# Altium schematic sheet CurrentSensor.SchDoc(CurrentSensor)
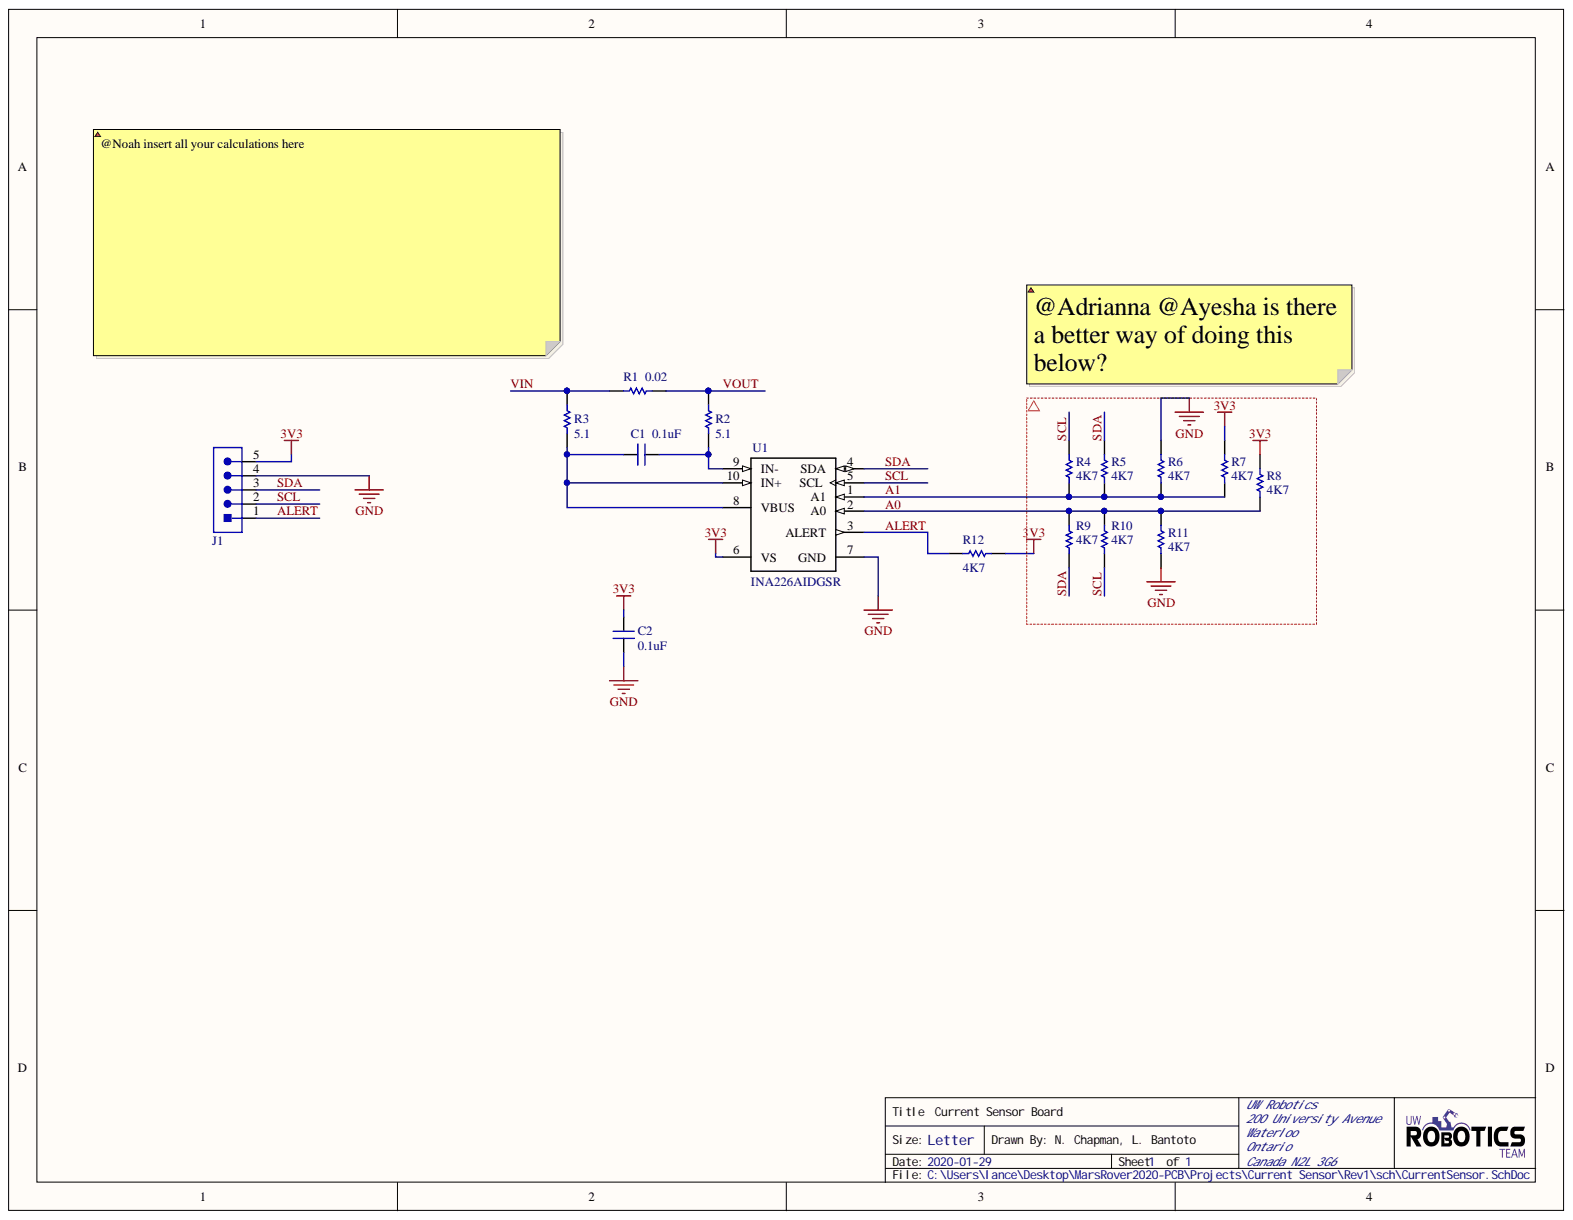
[diagram p0: CurrentSensor.SchDoc]

## Parts
C1  value 0.1uF  [CAPC2012X140N]  (hidden comment: CAP_0.1uF_50V_0805)
C2  value 0.1uF  [CAPC2012X140N]  (hidden comment: CAP_0.1uF_50V_0805)
J1  CON_5M_SM4  [JST-B5B-SM4-TB]  (value not drawn)
R1  value 0.02  [RESC6532X75N]  (hidden comment: RES_20m_2512)
R2  value 5.1  [RESC2013X70X40LL20T20]  (hidden comment: RES_5.1_0805)
R3  value 5.1  [RESC2013X70X40LL20T20]  (hidden comment: RES_5.1_0805)
R4  value 4K7  [RESC2012X60N]  (hidden comment: RES_4K7_0805)
R5  value 4K7  [RESC2012X60N]  (hidden comment: RES_4K7_0805)
R6  value 4K7  [RESC2012X60N]  (hidden comment: RES_4K7_0805)
R7  value 4K7  [RESC2012X60N]  (hidden comment: RES_4K7_0805)
R8  value 4K7  [RESC2012X60N]  (hidden comment: RES_4K7_0805)
R9  value 4K7  [RESC2012X60N]  (hidden comment: RES_4K7_0805)
R10  value 4K7  [RESC2012X60N]  (hidden comment: RES_4K7_0805)
R11  value 4K7  [RESC2012X60N]  (hidden comment: RES_4K7_0805)
R12  value 4K7  [RESC2012X60N]  (hidden comment: RES_4K7_0805)
U1  INA226AIDGSR  [INA226AIDGSR]

## Nets
3V3: C2.2 J1.5 R7.2 R8.2 R12.2 U1.6(VS)
GND: C2.1 J1.4 R6.2 R11.2 U1.7(GND)
A0: R8.1 R9.1 R10.1 R11.1 U1.2(A0)
A1: R4.1 R5.1 R6.1 R7.1 U1.1(A1)
ALERT: J1.1 R12.1 U1.3(ALERT)
SCL: J1.2 R4.2 R10.2 U1.5(SCL)
SDA: J1.3 R5.2 R9.2 U1.4(SDA)
VIN: R1.1 R3.2
VOUT: R1.2 R2.2
NetC1_1: C1.1 R3.1 U1.8(VBUS) U1.10(IN+)
NetC1_2: C1.2 R2.1 U1.9(IN-)

## Library data of the parts
C1 C2 = CAP_0.1uF_50V_0805: CAP CER 0.1UF 50V X7R 0805; Manufacturer Part Number 1: GCD21BR71H104KA01L; Manufacturer 1: Murata; Tolerance: 10%; Capacitance: 100nF; Width: 0.049inch; Voltage Rating: 50V; Thickness: 0.049inch; Termination: SMD/SMT; Supplier Part Number 1: 490-11955-1-ND; Supplier 1: Digi-Key; Ratings: AEC-Q200; Mount: Surface Mount; Min Operating Temperature: -55°C; Max Operating Temperature: 125°C; Length: 2mm; Height: 1.25mm; Dielectric: X7R; Depth: 1.25mm; ComponentLink1URL: https://datasheet.ciiva.com/29537/c03e-29537701.pdf?src-supplier=Digi-Key; ComponentLink1Description: https://datasheet.ciiva.com/29537/c03e-29537701.pdf?src-supplier=Digi-Key; Component Kind: Standard; Case Code (Metric): 2012; Case Code (Imperial): 0805; Case/Package: 0805; Library Name: UWRT_Schematic_Library_2020.SchLib
J1 = CON_5M_SM4: CONN HEADER SMD 5POS 2MM; Manufacturer Part Number: B5B-PH-SM4-TB(LF)(SN); Manufacturer: JST; Max Voltage Rating: 100V; Max Current Rating: 2A; Supplier Part Number 1: 455-1737-1-ND; Supplier 1: Digi-Key; Pitch: 2mm; ComponentLink5URL: https://datasheet.ciiva.com/16344/eph-16344920.pdf?src-supplier=Future+Electronics; ComponentLink5Description: https://datasheet.ciiva.com/16344/eph-16344920.pdf?src-supplier=Future+Electronics; ComponentLink4URL: https://datasheet.ciiva.com/730/eph-730612.pdf?src-supplier=Digi-Key; ComponentLink4Description: https://datasheet.ciiva.com/730/eph-730612.pdf?src-supplier=Digi-Key; ComponentLink3URL: https://datasheet.ciiva.com/712/eph-712596.pdf?src-supplier=Digi-Key; ComponentLink3Description: https://datasheet.ciiva.com/712/eph-712596.pdf?src-supplier=Digi-Key; ComponentLink2URL: https://datasheet.ciiva.com/712/eph-712595.pdf?src-supplier=Digi-Key; ComponentLink2Description: https://datasheet.ciiva.com/712/eph-712595.pdf?src-supplier=Digi-Key; ComponentLink1URL: https://datasheet.ciiva.com/11749/0900766b80ddea81-11749188.pdf?src-supplier=RSComponents; ComponentLink1Description: https://datasheet.ciiva.com/11749/0900766b80ddea81-11749188.pdf?src-supplier=RSComponents; Component Kind: Standard; Library Name: UWRT_Schematic_Library_2020.SchLib
R1 = RES_20m_2512: RES 0.02 OHM 1% 3W 2512; Manufacturer Part Number 1: CSRL3-0R02F8; Manufacturer 1: Riedon; Supplier Part Number 1: 696-1840-1-ND; Supplier 1: Digi-Key; RoHS: No; Pricing 1: 1=0.66000, 10=0.47400, 25=0.36920, 50=0.31260, 100=0.24620, 250=0.20836, 500=0.17048, 1000=0.13259 (USD); Component Kind: Standard; Library Name: UWRT_Schematic_Library_2020.SchLib
R2 R3 = RES_5.1_0805: RES SMD 5.1 OHM 5% 1/8W 0805; Part Number: ERJ-6GEYJ5R1V; Manufacturer: Panasonic; Supplier Part Number 1: P5.1ACT-ND; Supplier 1: Digi-Key; Component Kind: Standard; Library Name: UWRT_Schematic_Library_2020.SchLib
R4-R12 = RES_4K7_0805: RES SMD 4.7K OHM 5% 1/8W 0805; Manufacturer Part Number 1: RC0805JR-074K7L; Manufacturer 1: Yageo; Voltage Rating: 150V; Temperature Coefficient: 100ppm/°C; Resistance: 4.7kR; Power Rating: 125mW; Tolerance: 5%; Termination: SMD/SMT; Supplier Part Number 1: 311-4.7KARCT-ND; Supplier 1: Digi-Key; Stock 1: 2755291; RoHS: Yes; REACH SVHC: Unknown; Radiation Hardening: No; Pricing 1: 1=0.10000, 10=0.03500, 100=0.01400, 1000=0.00631, 2500=0.00548 (USD); Packaging: Tape and Reel; Min Operating Temperature: -55°C; Military Standard: Not; Max Operating Temperature: 155°C; Length: 2mm; Lead Free: Lead Free; Depth: 1.25mm; Contact Plating: Tin,Lead,Matte; Composition: Thick Film; ComponentLink4URL: https://datasheet.ciiva.com/12667/rc0805-12667037.pdf?src-supplier=Mouser; ComponentLink4Description: https://datasheet.ciiva.com/12667/rc0805-12667037.pdf?src-supplier=Mouser; ComponentLink3URL: https://datasheet.ciiva.com/17486/rc0805-17486827.pdf?src-supplier=Future+Electronics; ComponentLink3Description: https://datasheet.ciiva.com/17486/rc0805-17486827.pdf?src-supplier=Future+Electronics; ComponentLink2URL: https://datasheet.ciiva.com/7941/442941-7941974.pdf?src-supplier=Element14; ComponentLink2Description: https://datasheet.ciiva.com/7941/442941-7941974.pdf?src-supplier=Element14; ComponentLink1URL: https://datasheet.ciiva.com/7941/442941-7941973.pdf?src-supplier=Element14; ComponentLink1Description: https://datasheet.ciiva.com/7941/442941-7941973.pdf?src-supplier=Element14; Component Kind: Standard; Case Code (Metric): 2012; Case Code (Imperial): 0805; Case/Package: Molded; Library Name: UWRT_Schematic_Library_2020.SchLib
U1 = INA226AIDGSR: IC MONITOR PWR/CURR BIDIR 10MSOP; MF: Texas Instruments; Supplier Part Number 1: 296-29034-6-ND; Supplier 1: Digi-Key; SnapEDA_Link: https://www.snapeda.com/parts/INA226AIDGSR/Texas%20Instruments/view-part/53552/?ref=snap; Price: None; Package: MSOP-10 Texas Instruments; MP: INA226AIDGSR; Component Kind: Standard; Availability: Unavailable; Library Name: UWRT_Schematic_Library_2020.SchLib
